# Altium schematic sheet Power.SchDoc
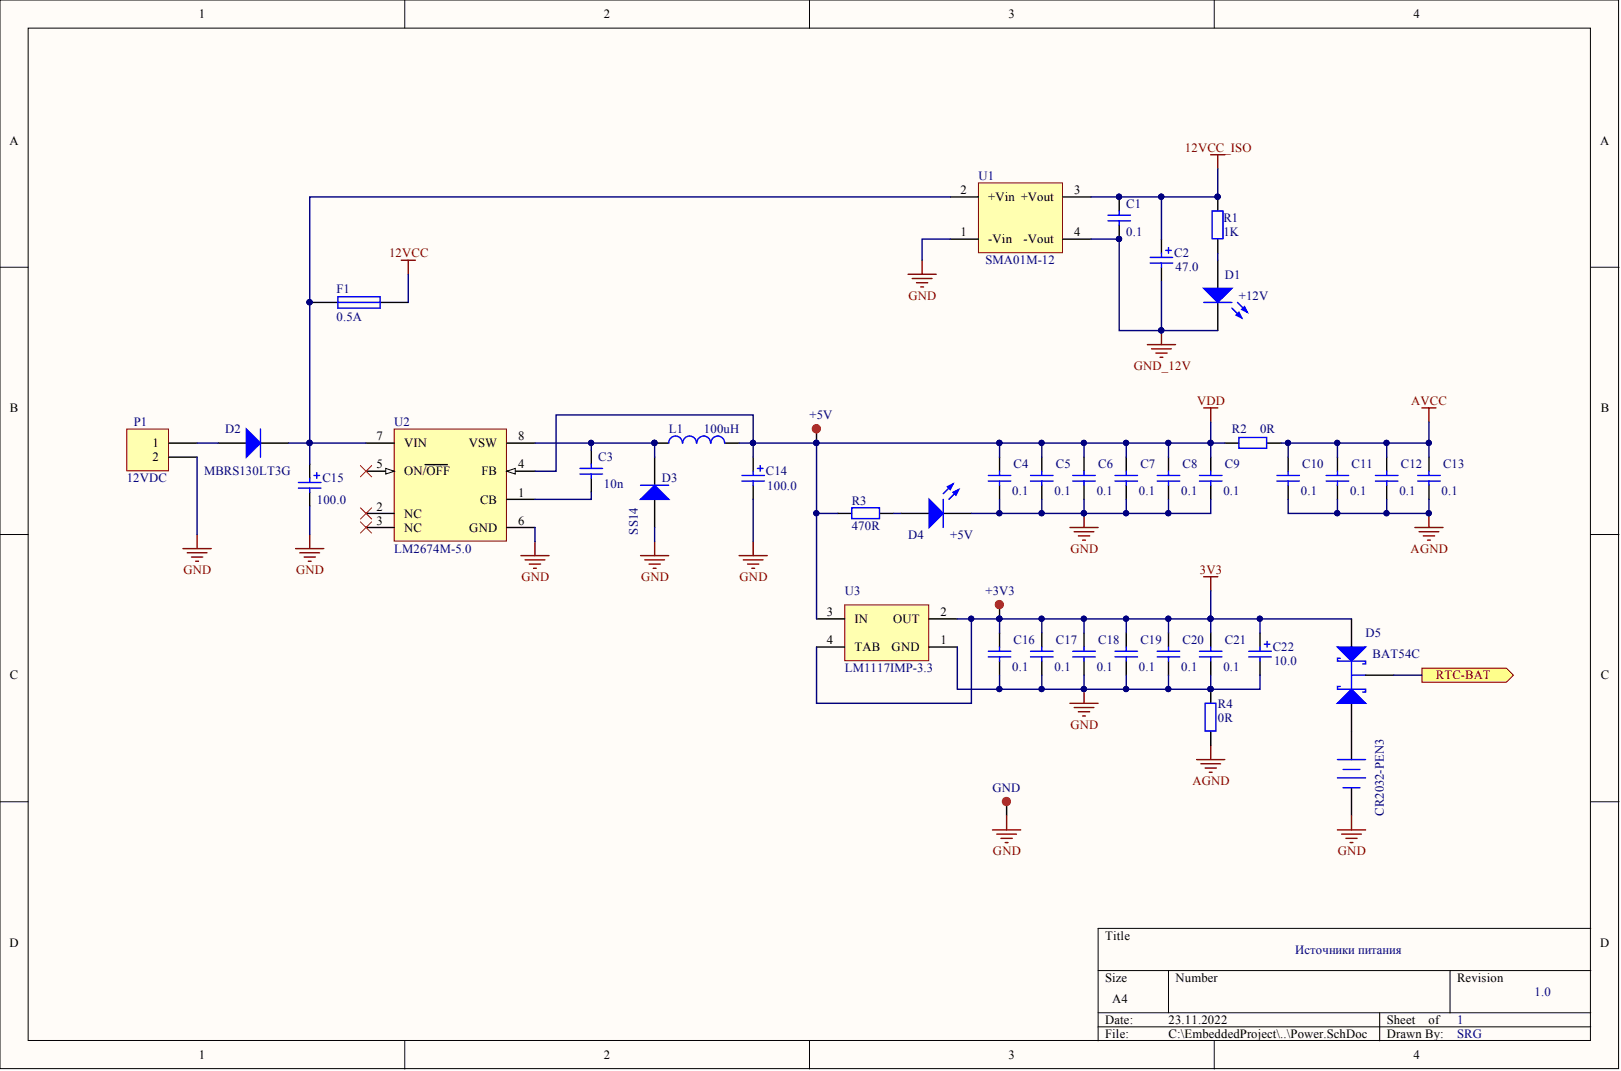
[diagram p0: Power.SchDoc]

## Parts
+3V3  Control mark  [Control mark]  (value not drawn)
+5V  Control mark  [Control mark]  (value not drawn)
BAT  value CR2032-PEN3  [KLS5-CR1220]  (hidden comment: BAT 3V3)
C1  value 0.1  [C1206]  (hidden comment: Cap)
C2  value 47.0  [D]  (hidden comment: TECAP47/20VD10)
C3  value 10n  [C1206]  (hidden comment: Cap)
C4  value 0.1  [C1206]  (hidden comment: Cap)
C5  value 0.1  [C1206]  (hidden comment: Cap)
C6  value 0.1  [C1206]  (hidden comment: Cap)
C7  value 0.1  [C1206]  (hidden comment: Cap)
C8  value 0.1  [C1206]  (hidden comment: Cap)
C9  value 0.1  [C1206]  (hidden comment: Cap)
C10  value 0.1  [C1206]  (hidden comment: Cap)
C11  value 0.1  [C1206]  (hidden comment: Cap)
C12  value 0.1  [C1206]  (hidden comment: Cap)
C13  value 0.1  [C1206]  (hidden comment: Cap)
C14  value 100.0  [D]  (hidden comment: TECAP47/20VD10)
C15  value 100.0  [EL SMD D8 h6.5]  (hidden comment: TECAP22/25VD10)
C16  value 0.1  [C1206]  (hidden comment: Cap)
C17  value 0.1  [C1206]  (hidden comment: Cap)
C18  value 0.1  [C1206]  (hidden comment: Cap)
C19  value 0.1  [C1206]  (hidden comment: Cap)
C20  value 0.1  [C1206]  (hidden comment: Cap)
C21  value 0.1  [C1206]  (hidden comment: Cap)
C22  value 10.0  [D]  (hidden comment: TECAP47/20VD10)
D1  +12V  [LED1206 - BLUE]
D2  MBRS130LT3G  [DO-214AA (SMB)]
D3  SS14  [DO-214AA (SMB)]
D4  +5V  [LED1206 - GREEN]
D5  value BAT54C  [SOT23_BAT54]  (hidden comment: Schottky Barrier Double Diode)
F1  0.5A  [1812]
GND  Control mark  [Control mark]  (value not drawn)
L1  value 100uH  [cd74r]  (hidden comment: SDR1006-680KL)
P1  +12V  value 12VDC  [FFKDSA-2P]
R1  value 1K  [R1206]  (hidden comment: 520)
R2  value 0R  [R1206]  (hidden comment: 520)
R3  value 470R  [R1206]  (hidden comment: 520)
R4  value 0R  [R1206]  (hidden comment: 520)
U1  SMA01M-12  [SMAU01M-12]
U2  LM2674M-5.0  [M08A_N]
U3  LM1117IMP-3.3  [MP04A_N]

## Ports
RTC-BAT

## Nets
3V3: +3V3.1 C16.2 C17.2 C18.2 C19.2 C20.2 C21.2 C22.1 D5.2 U3.2(OUT) U3.4(TAB)
12VCC: F1.1
12VCC_ISO: C1.2 C2.1 R1.1 U1.3(+Vout)
AGND: C10.1 C11.1 C12.1 C13.1 R4.2
AVCC: C10.2 C11.2 C12.2 C13.2 R2.2
GND: BAT.2 C4.1 C5.1 C6.1 C7.1 C8.1 C9.1 C14.2 C15.2 C16.1 C17.1 C18.1 C19.1 C20.1 C21.1 C22.2 D3.1 D4.2 GND.1 P1.2 R4.1 U1.1(-Vin) U2.6(GND) U3.1(GND)
GND_12V: C1.1 C2.2 D1.2 U1.4(-Vout)
VDD: +5V.1 C4.2 C5.2 C6.2 C7.2 C8.2 C9.2 C14.1 L1.2 R2.1 R3.1 U2.4(FB) U3.3(IN)
RTC-BAT: D5.3 ; port RTC-BAT
NetBAT_1: BAT.1 D5.1
NetC3_1: C3.1 D3.2 L1.1 U2.8(VSW)
NetC3_2: C3.2 U2.1(CB)
NetC15_1: C15.1 D2.2 F1.2 U1.2(+Vin) U2.7(VIN)
NetD1_1: D1.1 R1.2
NetD2_1: D2.1 P1.1
NetD4_1: D4.1 R3.2

Not connected: U2 2(NC) 3(NC) 5(ON/OFF)

## Library data of the parts
BAT = Battery: Multicell Battery; PartNumber: CR2032-PEN3; DeliveryTime: 7; Value: CR2032-PEN3; Component Kind: Standard; Library Name: Miscellaneous Devices.IntLib; Price: 42.00
C1 C4-C13 C16-C21 = Cap: SMD 1206 Capasitor Body 3.2 x 1.6 mm; PartNumber: GRM31CR72A104K; DeliveryTime: 7; Component Kind: Standard; Library Name: Miscellaneous Devices.IntLib; Price: 10.00; Signal Integrity: Cap; Simulation: CAP
C2 C14 C22 = Cap Pol2: Capacitor, SM Tantalum; Body 7.3 x 4.3 mm; Component Kind: Standard; LatestRevisionDate: 17-Jul-2002; LatestRevisionNote: Re-released for DXP Platform.; Library Name: Miscellaneous Devices.IntLib; PackageDescription: Polarized Capacitor; 2 Leads; PackageReference: POLAR0.8; Published: 8-Jun-2000; Signal Integrity: Cap Pol; Simulation: CAP; Publisher: Altium Limited
C3 = Cap: SMD 1206 Capasitor Body 3.2 x 1.6 mm; Component Kind: Standard; LatestRevisionDate: 17-Jul-2002; LatestRevisionNote: Re-released for DXP Platform.; Library Name: Miscellaneous Devices.IntLib; PackageReference: RAD-0.3; Published: 8-Jun-2000; Signal Integrity: Cap; Simulation: CAP; Publisher: Altium Limited
C15 = Cap Pol2: EL SMD D8 h6.5; Component Kind: Standard; LatestRevisionDate: 17-Jul-2002; LatestRevisionNote: Re-released for DXP Platform.; Library Name: Miscellaneous Devices.IntLib; PackageDescription: Polarized Capacitor; 2 Leads; PackageReference: POLAR0.8; Published: 8-Jun-2000; Signal Integrity: Cap Pol; Simulation: CAP; Publisher: Altium Limited
D1 = LED1: SMD LED; Value: BLUE; Component Kind: Standard; LatestRevisionDate: 08-Jul-2005; LatestRevisionNote: Mech Layer 1 removed.; Library Name: Miscellaneous Devices.IntLib; PackageReference: LED-1; Published: 8-Jun-2000; Simulation: LED1; Publisher: Altium Limited
D2 D3 = Diode: Schottky Power Rectifier; Code_JEDEC: DO-214-AB; Component Kind: Standard; LatestRevisionDate: 17-Jul-2002; LatestRevisionNote: Re-released for DXP Platform.; Library Name: Miscellaneous Devices.IntLib; PackageDescription: DO-214-AB/SMC; 2 C-Bend Leads; Body 7.9 x 5.9 mm, inc. leads (LxW); PackageReference: SMC; PackageVersion: Sep-1996; Published: 8-Jun-2000; Signal Integrity: Diode; Simulation: DIODE; Publisher: Altium Limited
  D2: Value: MBRS130LT3G
  D3: Value: SS14
D4 = LED1: SMD LED; Value: GREEN; Component Kind: Standard; LatestRevisionDate: 08-Jul-2005; LatestRevisionNote: Mech Layer 1 removed.; Library Name: Miscellaneous Devices.IntLib; PackageReference: LED-1; Published: 8-Jun-2000; Simulation: LED1; Publisher: Altium Limited
D5 = BAT54C: DeliveryTime: 7; Component Kind: Standard; Description: Schottky Barrier Double Diode; Library Name: Philips Discrete Diode - Schottky.IntLib; PartNUmber: BAT54C; Price: 4.00; Signal Integrity: BAT54C; Simulation: BAT54C
F1 = Fuse 1: Fuse; Value: LP-MSM050-2, 15V 0.5A; Component Kind: Standard; LatestRevisionDate: 17-Jul-2002; LatestRevisionNote: Re-released for DXP Platform.; Library Name: Miscellaneous Devices.IntLib; PackageDescription: Thru-Hole; 2 Leads; PackageReference: PIN-W2/E2.8; Published: 8-Jun-2000; Simulation: FUSE; Publisher: Altium Limited
L1 = Inductor: Art: B82472G6683M000; Code_IEC: 1005; Code_JEITA: 0402; Component Kind: Standard; Description: Inductor; LatestRevisionDate: 29-May-2009; LatestRevisionNote: IPC-7351 Footprint Added.; Library Name: Miscellaneous Devices.IntLib; PackageDescription: Chip Inductor; PackageReference: 0402-A; Published: 8-Jun-2000; Signal Integrity: Inductor; Simulation: INDUCTOR; Publisher: Altium Limited
P1 = Header 2: Header, 2-Pin; Component Kind: Standard; LatestRevisionDate: 17-Jul-2002; LatestRevisionNote: Re-released for DXP Platform.; Library Name: Miscellaneous Connectors.IntLib; PartNumber: FFKDSA-2P; Signal Integrity: Connector; Publisher: Altium Limited
R1-R4 = Res2: SMD 1206 Resistor Body 3.2 x 1.6 mm; Component Kind: Standard; LatestRevisionDate: 17-Jul-2002; LatestRevisionNote: Re-released for DXP Platform.; Library Name: Miscellaneous Devices.IntLib; PackageReference: AXIAL-0.4; Published: 8-Jun-2000; Signal Integrity: Res; Simulation: RESISTOR; Publisher: Altium Limited
U1 = SMA01M-12: DC/DC 12/12VDC; Component Kind: Standard; Library Name: Schlib1.SchLib; Value: SMA01M-12
U2 = LM2675M-5.0: SIMPLE SWITCHER® Power Converter High Efficiency 1A Step-Down Voltage Regulator, 8-pin Narrow SOIC; Manufacturer: National Semiconductor; High-Reliability: Y; PackageTopMarking: NSZXTT*2675*M5.0; PowerWise: N; Code_IPC: SOIC127P600-8; Code_JEDEC: MS-012-AA; Component Kind: Standard; ComponentLink1Description: Manufacturer URL; ComponentLink1URL: http://www.national.com/; ComponentLink2Description: LM2674 Datasheet; ComponentLink2URL: http://www.national.com/ds/LM/LM2675.pdf; ComponentLink3Description: LM2674 Web Page; ComponentLink3URL: http://www.national.com/pf/LM/LM2675.html; ComponentLink4Description: M08A Package Page; ComponentLink4URL: http://www.national.com/packaging/folders/m08a.html; CompType: Switcher; DatasheetVersion: Jun-2005; Library Name: National Semiconductor Switcher.IntLib; PackageDescription: SOIC Narrow, 4.9 x 3.9 x 1.45mm, 8 Lead, 1.27mm Pitch; PackageReference: M08A; PackageVersion: revM, May-2009; PartNumber: LM2674M-5.0; PinCount: 8; RoHS: N
U3 = LM1117IMP-3.3: 800mA Low-Dropout Linear Regulator, 4-pin SOT-223; PartNumber: LM1117IMP-3.3/NOPB; DeliveryTime: 7; Component Kind: Standard; Library Name: National Semiconductor LDO.IntLib; Price: 43.00; Value: LM1117IMP-3.3
+3V3 +5V GND = Control mark: Component Kind: Standard; Library Name: Schlib1.SchLib
